# Altium schematic sheet Extra2_temp_amp.SchDoc
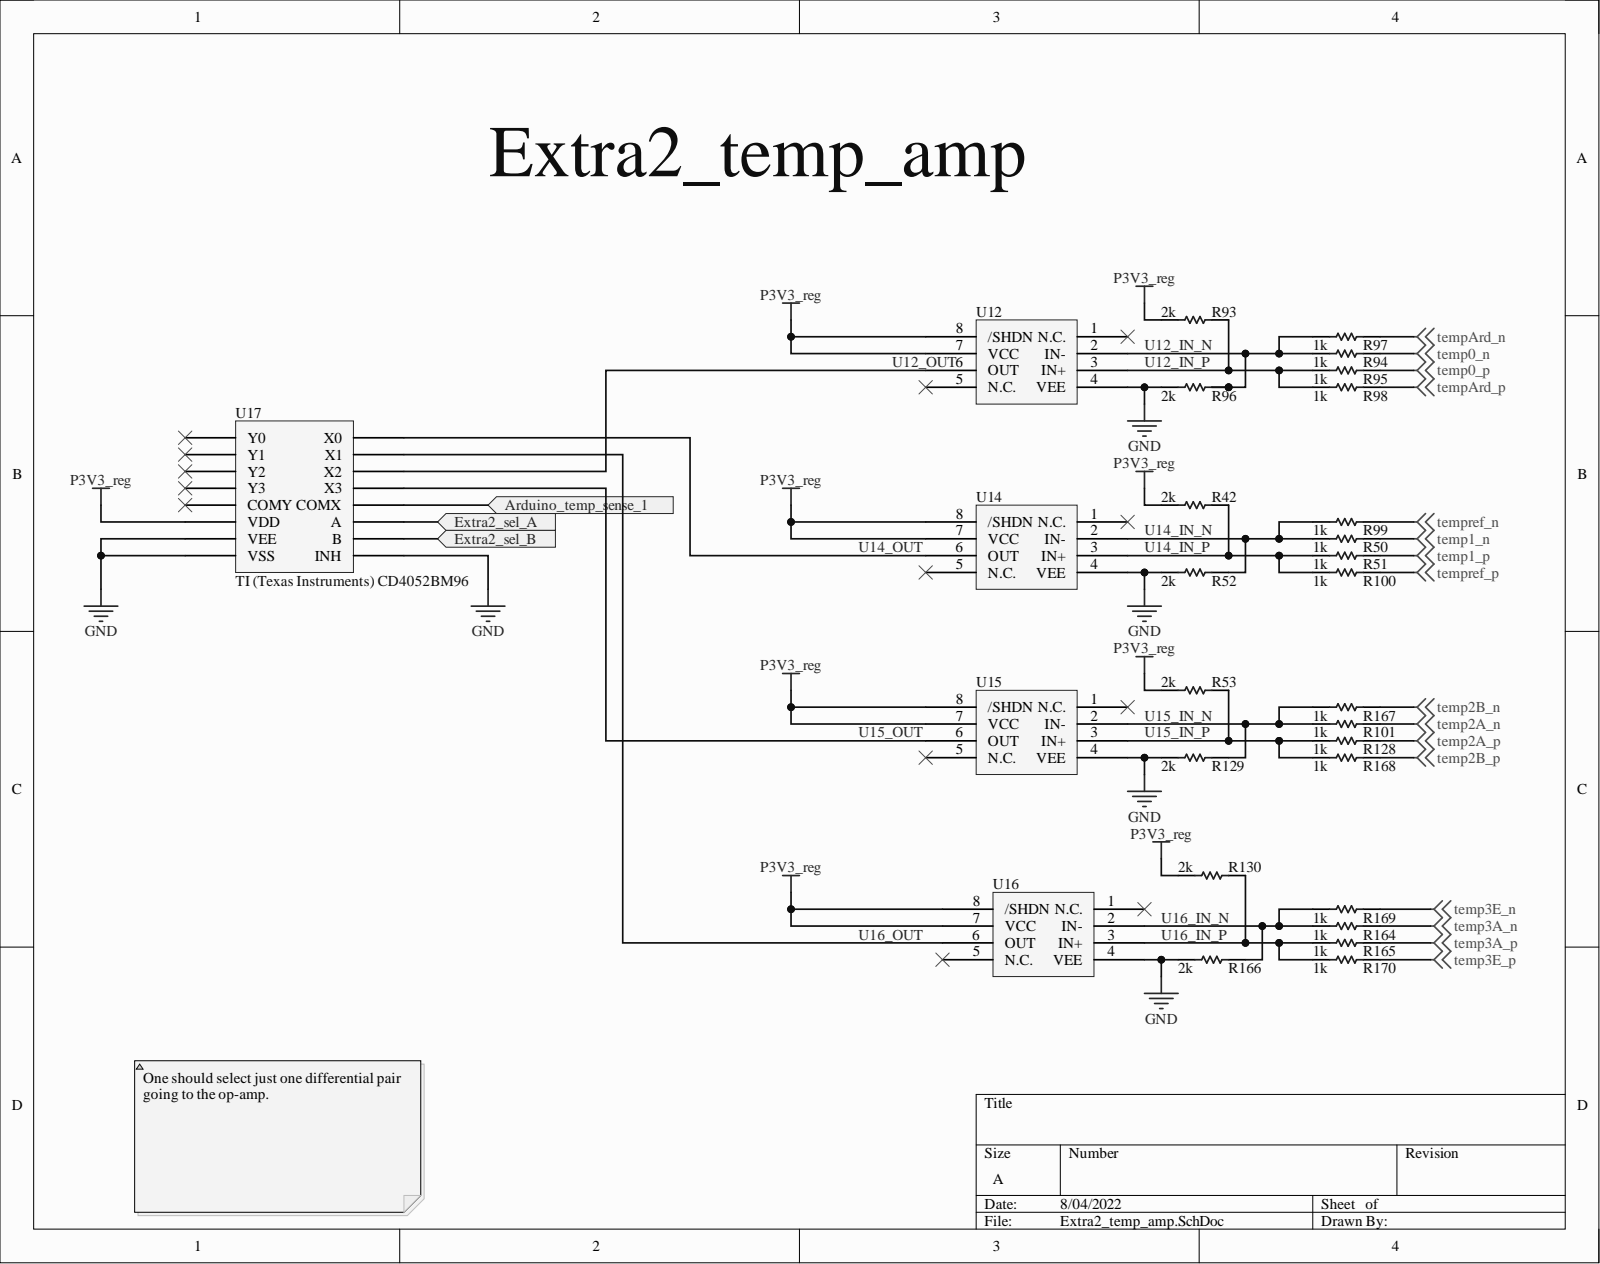
[diagram p0: Extra2_temp_amp.SchDoc]

## Parts
R42  2k  [PCB-0002-00743-1]
R50  1k  [PCB-inhgnewug1oc5mowfht0-1]
R51  1k  [PCB-inhgnewug1oc5mowfht0-1]
R52  2k  [PCB-0002-00743-1]
R53  2k  [PCB-0002-00743-1]
R93  2k  [PCB-0002-00743-1]
R94  1k  [PCB-inhgnewug1oc5mowfht0-1]
R95  1k  [PCB-inhgnewug1oc5mowfht0-1]
R96  2k  [PCB-0002-00743-1]
R97  1k  [PCB-inhgnewug1oc5mowfht0-1]
R98  1k  [PCB-inhgnewug1oc5mowfht0-1]
R99  1k  [PCB-inhgnewug1oc5mowfht0-1]
R100  1k  [PCB-inhgnewug1oc5mowfht0-1]
R101  1k  [PCB-inhgnewug1oc5mowfht0-1]
R128  1k  [PCB-inhgnewug1oc5mowfht0-1]
R129  2k  [PCB-0002-00743-1]
R130  2k  [PCB-0002-00743-1]
R164  1k  [PCB-inhgnewug1oc5mowfht0-1]
R165  1k  [PCB-inhgnewug1oc5mowfht0-1]
R166  2k  [PCB-0002-00743-1]
R167  1k  [PCB-inhgnewug1oc5mowfht0-1]
R168  1k  [PCB-inhgnewug1oc5mowfht0-1]
R169  1k  [PCB-inhgnewug1oc5mowfht0-1]
R170  1k  [PCB-inhgnewug1oc5mowfht0-1]
U12  Maxim Integrated MAX4236BESA+  [PCB-6ninsl2791hbem3bfzi8-1]  (value not drawn)
U14  Maxim Integrated MAX4236BESA+  [PCB-6ninsl2791hbem3bfzi8-1]  (value not drawn)
U15  Maxim Integrated MAX4236BESA+  [PCB-6ninsl2791hbem3bfzi8-1]  (value not drawn)
U16  Maxim Integrated MAX4236BESA+  [PCB-6ninsl2791hbem3bfzi8-1]  (value not drawn)
U17  TI (Texas Instruments) CD4052BM96  Manufacturer Part Number: CD4052BM96  [PCB-1172659-1]

## Ports
Arduino_temp_sense_1, Extra2_sel_A, Extra2_sel_B

## Nets
GND: R52.1 R96.1 R129.1 R166.1 U12.4(VEE) U14.4(VEE) U15.4(VEE) U16.4(VEE) U17.6(INH) U17.7(VEE) U17.8(VSS)
P3V3_reg: R42.1 R53.1 R93.1 R130.1 U12.7(VCC) U12.8(/SHDN) U14.7(VCC) U14.8(/SHDN) U15.7(VCC) U15.8(/SHDN) U16.7(VCC) U16.8(/SHDN) U17.16(VDD)
temp0_n: R94.2
temp0_p: R95.2
temp1_n: R50.2
temp1_p: R51.2
temp2A_n: R101.2
temp2A_p: R128.2
temp2B_n: R167.2
temp2B_p: R168.2
temp3A_n: R164.2
temp3A_p: R165.2
temp3E_n: R169.2
temp3E_p: R170.2
tempArd_n: R97.2
tempArd_p: R98.2
tempref_n: R99.2
tempref_p: R100.2
Arduino_temp_sense_1: U17.13(COMX) ; port Arduino_temp_sense_1
Extra2_sel_A: U17.10(A) ; port Extra2_sel_A
Extra2_sel_B: U17.9(B) ; port Extra2_sel_B
U12_IN_N: R94.1 R96.2 R97.1 U12.2(IN-)
U12_IN_P: R93.2 R95.1 R98.1 U12.3(IN+)
U12_OUT: U12.6(OUT) U17.15(X2)
U14_IN_N: R50.1 R52.2 R99.1 U14.2(IN-)
U14_IN_P: R42.2 R51.1 R100.1 U14.3(IN+)
U14_OUT: U14.6(OUT) U17.12(X0)
U15_IN_N: R101.1 R129.2 R167.1 U15.2(IN-)
U15_IN_P: R53.2 R128.1 R168.1 U15.3(IN+)
U15_OUT: U15.6(OUT) U17.11(X3)
U16_IN_N: R164.1 R166.2 R169.1 U16.2(IN-)
U16_IN_P: R130.2 R165.1 R170.1 U16.3(IN+)
U16_OUT: U16.6(OUT) U17.14(X1)

Not connected: U12 1(N.C.) 5(N.C.); U14 1(N.C.) 5(N.C.); U15 1(N.C.) 5(N.C.); U16 1(N.C.) 5(N.C.); U17 1(Y0) 2(Y2) 3(COMY) 4(Y3) 5(Y1)

## Library data of the parts
R42 R52 R53 R93 R96 R129 R130 R166 = CMP-e4e22df909e63b25-3: Res Thick Film 0805 3K Ohm 1% 0.125W(1/8W) ±100ppm/C Pad SMD T/R; Manufacturer Part Number: RC0805FR-073KL; Case/Package (SI): 2012; Lead-Free Status: Lead Free; Power Rating: 125 mW; Resistance: 3.00 kΩ; Temperature Coefficient: -100 ppm/°C to 100 ppm/°C; Voltage Rating (DC): 150 V; Case/Package: 0805; Component Kind: Standard; Library Name: My Personal Space; Mounting Style: Surface Mount; Octopart URL: https://octopart.com/rc0805fr-073kl-yageo-1165410; OctopartID: e4e22df909e63b25; Operating Temperature: 155 °C (max); Packaging: Tape & Reel; Resistance Tolerance: ±1%; RoHS: Compliant; Size-Height: 500 µm; Size-Length: 2.00 mm; Size-Width: 1.25 mm
R50 R51 R94 R95 R97-R101 R128 R164 R165 R167-R170 = CMP-5a329075facc85f5-3: Res Thin Film 0805 1K Ohm 0.1% 1/8W ±10ppm/°C Molded SMD SMD Punched Carrier T/R; Component Kind: Standard; Library Name: My Personal Space
U12 U14-U16 = CMP-c91802911c2a16df-1: Amplifiers, Buffers, soic, pin, Semiconductors and Actives, single, opamp, amp, ic, Operational Amplifiers (General P...; Bandwidth: 1.70 MHz; Slew Rate: 300 mV/μs; Supply Voltage (DC): 6.00 V; Component Kind: Standard; Library Name: My Personal Space; Lifecycle Status: Not Listed by Manufacturer; Manufacturer Part Number: MAX4236BESA+; Number of Pins: 8; Octopart URL: http://octopart.com/max4236besa%2B-maxim+integrated-42201172; OctopartID: c91802911c2a16df; RoHS: Compliant
U17 = CMP-1172659-2: IC, ANALOG MUX/DMUX, DUAL 4 X 1, SOIC-16; Contacts Type: SP4T; Lead-Free Status: Lead Free; Number of Bits: 4; Polarity: Non-Inverting; Quiescent Current: 8e-08 A; Supply Current: 0.0001 A; Supply Voltage (DC): 15.0 V; Component Kind: Standard; Library Name: My Personal Space; Lifecycle Status: Active; Mounting Style: Surface Mount; Number of Pins: 16; Octopart Url: https://octopart.com/cd4052bm96-texas+instruments-472253; OctopartID: 5f4a96474e91808d; Packaging: Tape & Reel (TR); REACH SVHC Compliance: No SVHC; RoHS: Compliant
